# Altium schematic sheet MCU_USB_NEGOTIATOR.SchDoc
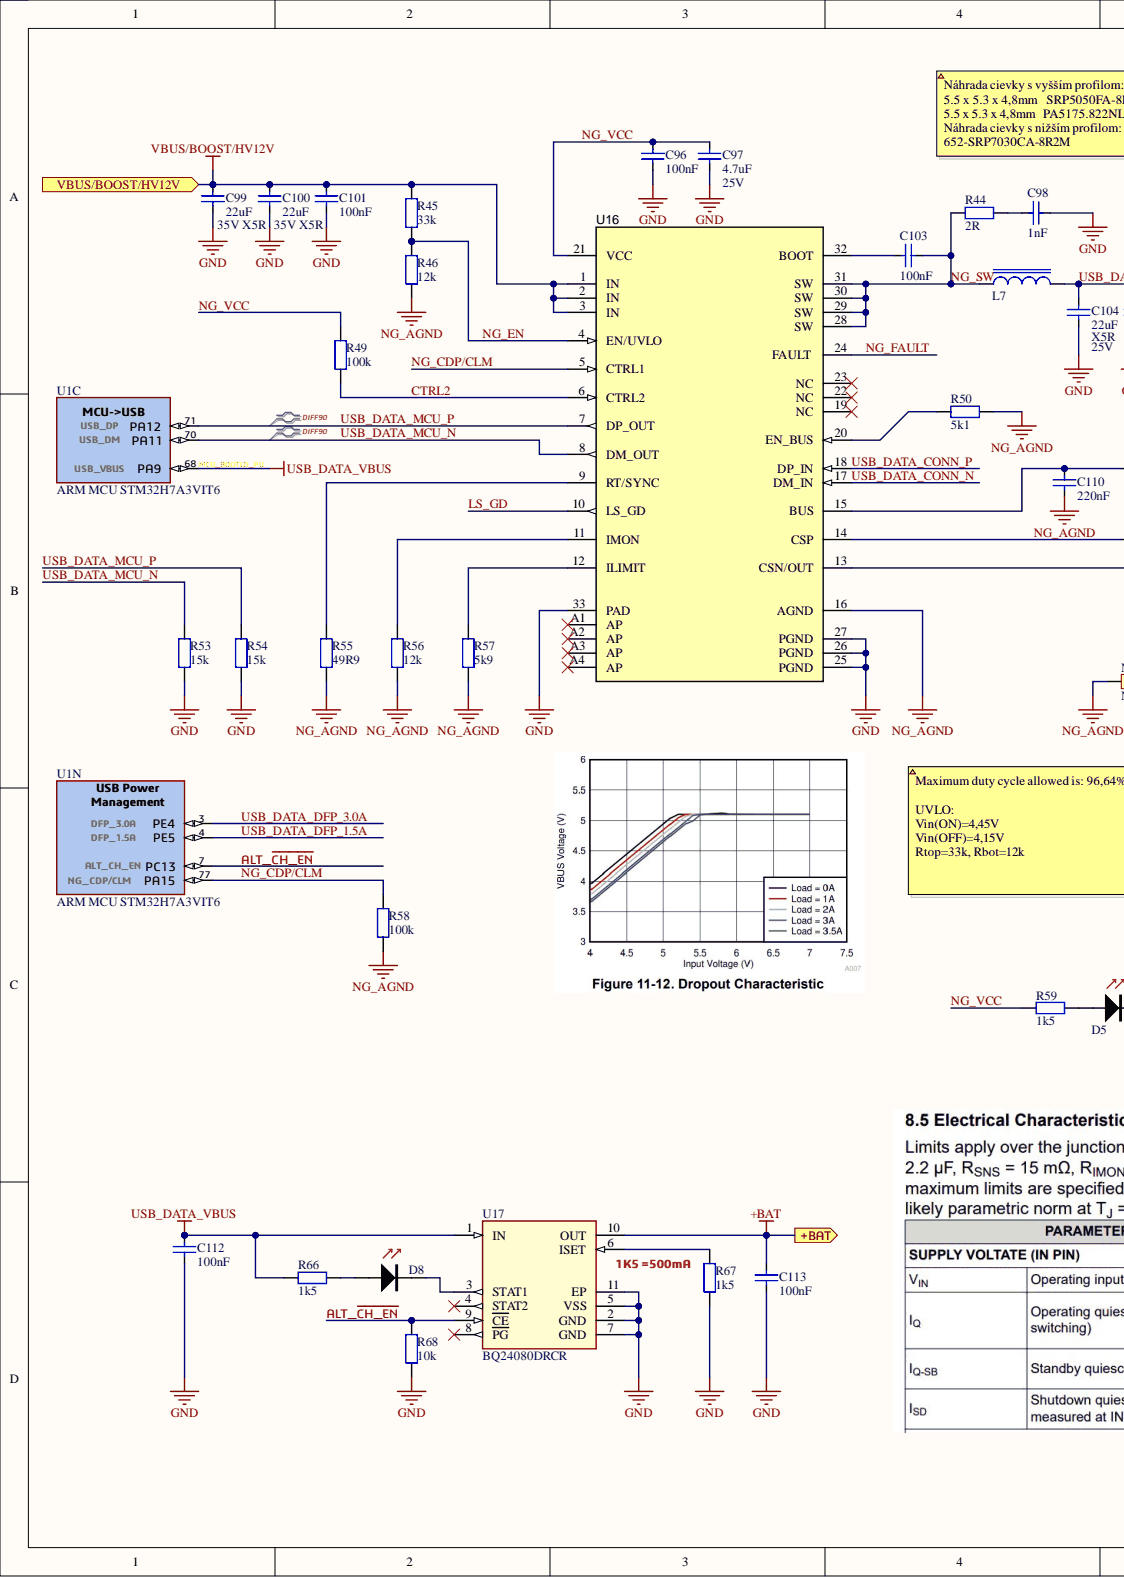
[diagram p0: MCU_USB_NEGOTIATOR.SchDoc, left]
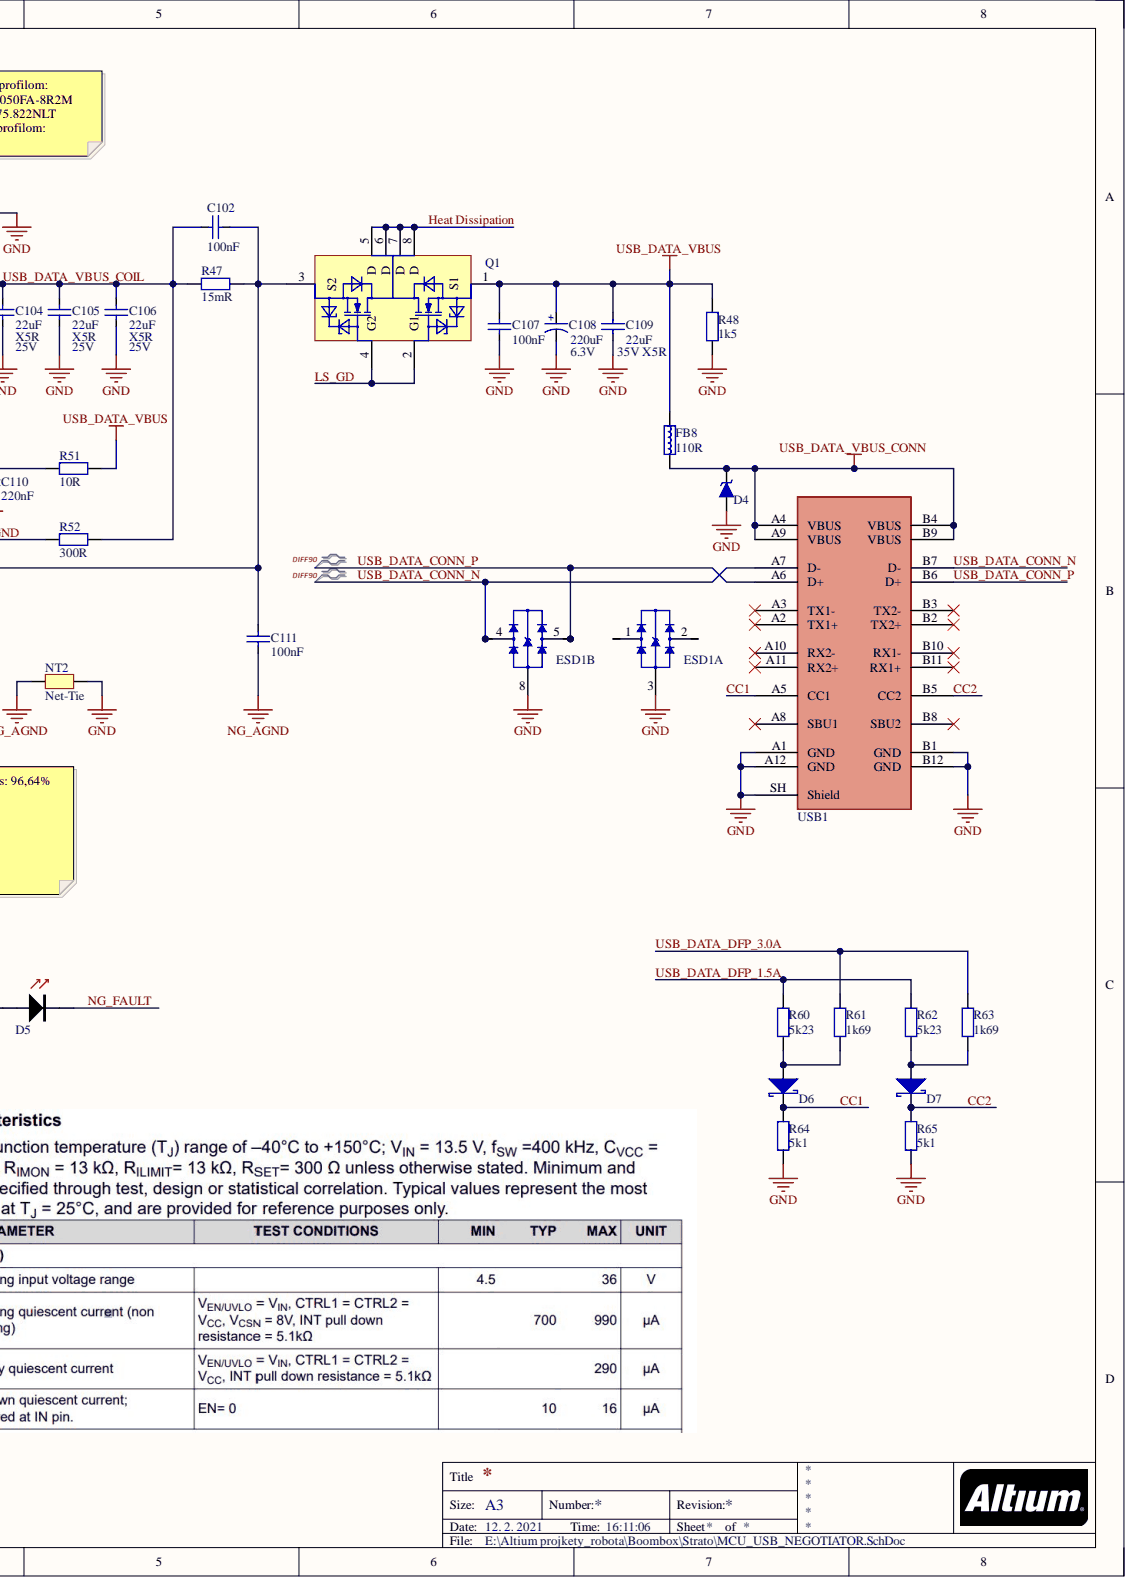
[diagram p1: MCU_USB_NEGOTIATOR.SchDoc, right]

## Parts
C96  100nF  [CAPACITOR_0402_(1005)]
C97  4.7uF  Voltage: 25V  [CAPACITOR_0603_(1608)]
C98  1nF  [CAPACITOR_0603_(1608)]
C99  22uF  Voltage Rating (DC): 35V  [CAPACITOR_1206_(3216)]
C100  22uF  Voltage Rating: 35V X5R  Voltage Rating (DC): 35V  [CAPACITOR_1206_(3216)]
C101  100nF  [CAPACITOR_0402_(1005)]
C102  100nF  [CAPACITOR_0402_(1005)]
C103  100nF  [CAPACITOR_0402_(1005)]
C104  22uF  Dielectric: X5R  Voltage: 25V  [CAPACITOR_0805_(2012)]
C105  22uF  Dielectric: X5R  Voltage: 25V  [CAPACITOR_0805_(2012)]
C106  22uF  Dielectric: X5R  Voltage: 25V  [CAPACITOR_0805_(2012)]
C107  100nF  [CAPACITOR_0402_(1005)]
C108  220uF  Voltage Rating: 6.3V  [Cap_tantalum Case B 3528-21]
C109  22uF  Voltage Rating: 35V X5R  Voltage Rating (DC): 35V  [CAPACITOR_1206_(3216)]
C110  220nF  [CAPACITOR_0603_(1608)]
C111  100nF  [CAPACITOR_0402_(1005)]
C112  100nF  [CAPACITOR_0402_(1005)]
C113  100nF  [CAPACITOR_0402_(1005)]
D4  Transient Voltage Suppressor  [MicroSMP DO-219AD]  (value not drawn)
D5  LED RED  [LED_0805_RED]  (value not drawn)
D6  NSR10F30NXT5G  [D_DSN2_(0502)]  (value not drawn)
D7  NSR10F30NXT5G  [D_DSN2_(0502)]  (value not drawn)
D8  LED RED  [LED_0805_RED]  (value not drawn)
ESD1  ESD Suppressor  [DQA10]  (value not drawn)  (parts A,B)
FB8  110R  [FERRITE BEAD_1206_(3216)]
L7  SRP5050FA-8R2M  [Power Inductor_SRP5050FA(SMD)  Bourns]
NT2  Net-Tie  [Net-Tie]  (no BOM)
Q1  ECH8693R-TL-W  [SOT-28FL / ECH8]  (value not drawn)
R44  2R  [RESISTOR_0603_(1608)]
R45  33k  [RESISTOR_0603_(1608)]
R46  12k  [RESISTOR_0603_(1608)]
R47  15mR  [RESISTOR_1206_(3216)]
R48  1k5  [RESISTOR_0603_(1608)]
R49  100k  [RESISTOR_0603_(1608)]
R50  5k1  [RESISTOR_0603_(1608)]
R51  10R  [RESISTOR_0603_(1608)]
R52  300R  [RESISTOR_0603_(1608)]
R53  15k  [RESISTOR_0402_(1005)]
R54  15k  [RESISTOR_0402_(1005)]
R55  49R9  [RESISTOR_0603_(1608)]
R56  12k  [RESISTOR_0603_(1608)]
R57  5k9  [RESISTOR_0603_(1608)]
R58  100k  [RESISTOR_0603_(1608)]
R59  1k5  [RESISTOR_0603_(1608)]
R60  5k23  [RESISTOR_0603_(1608)]
R61  1k69  [RESISTOR_0603_(1608)]
R62  5k23  [RESISTOR_0603_(1608)]
R63  1k69  [RESISTOR_0603_(1608)]
R64  5k1  [RESISTOR_0603_(1608)]
R65  5k1  [RESISTOR_0603_(1608)]
R66  1k5  [RESISTOR_0603_(1608)]
R67  1k5  [RESISTOR_0603_(1608)]
R68  10k  [RESISTOR_0603_(1608)]
U1  ARM MCU STM32H7A3VIT6  Manufacturer Part Number: STM32H7A3VIT6  [STM-LQFP100_N - Edited]  (parts C,N)
U16  TPS25846-Q1  [VQFN32-RHB 32]  (value not drawn)
U17  BQ24080DRCR  [VSON10]
USB1  DX07W024JA1R1000  [USB Type C DX07W024JA1]  (value not drawn)

## Ports
+BAT, VBUS/BOOST/HV12V

## Nets
GND: C96.2 C97.2 C98.2 C99.2 C100.2 C101.2 C104.1 C105.1 C106.1 C107.2 C108.1 C109.2 C112.2 C113.2 D4.1 ESD1.3 ESD1.8 NT2.2 R48.1 R53.2 R54.2 R64.2 R65.2 R67.2 R68.1 U16.25(PGND) U16.26(PGND) U16.27(PGND) U16.33(PAD) U17.2(GND) U17.5(VSS) U17.7(GND) U17.11(EP) USB1.A1(GND) USB1.A12(GND) USB1.B1(GND) USB1.B12(GND) USB1.SH(Shield)
NG_AGND: C110.1 C111.1 NT2.1 R46.2 R50.2 R55.2 R56.2 R57.2 R58.1 U16.16(AGND)
USB_DATA_VBUS: C107.1 C108.2 C109.1 C112.1 FB8.1 Q1.1(S1) R48.2 R51.2 R66.1 U1.68(PA9) U17.1(IN)
USB_DATA_VBUS_CONN: D4.2 FB8.2 USB1.A4(VBUS) USB1.A9(VBUS) USB1.B4(VBUS) USB1.B9(VBUS)
+BAT: C113.1 U17.10(OUT) ; port +BAT
ALT_~{CH_EN}: R68.2 U1.7(PC13) U17.9(CE)
CC1: D6.1 R64.1 USB1.A5(CC1)
CC2: D7.1 R65.1 USB1.B5(CC2)
CTRL2: R49.1 U16.6(CTRL2)
Heat Dissipation: Q1.5(D) Q1.6(D) Q1.7(D) Q1.8(D)
LS_GD: Q1.2(G1) Q1.4(G2) U16.10(LS_GD)
NG_CDP/CLM: R58.2 U1.77(PA15) U16.5(CTRL1)
NG_EN: R45.2 R46.1 U16.4(EN/UVLO)
NG_FAULT: D5.K U16.24(FAULT)
NG_SW: C103.2 L7.1 R44.1 U16.28(SW) U16.29(SW) U16.30(SW) U16.31(SW)
NG_VCC: C96.1 C97.1 R49.2 R59.1 U16.21(VCC)
USB_DATA_CONN_N: ESD1.4 ESD1.7 U16.17(DM_IN) USB1.A7(D-) USB1.B7(D-)
USB_DATA_CONN_P: ESD1.5 ESD1.6 U16.18(DP_IN) USB1.A6(D+) USB1.B6(D+)
USB_DATA_DFP_1.5A: R60.1 R62.1 U1.4(PE5)
USB_DATA_DFP_3.0A: R61.1 R63.1 U1.3(PE4)
USB_DATA_MCU_N: R53.1 U1.70(PA11) U16.8(DM_OUT)
USB_DATA_MCU_P: R54.1 U1.71(PA12) U16.7(DP_OUT)
USB_DATA_VBUS_COIL: C102.1 C104.2 C105.2 C106.2 L7.2 R47.2 R52.2
VBUS/BOOST/HV12V: C99.1 C100.1 C101.1 R45.1 U16.1(IN) U16.2(IN) U16.3(IN) ; port VBUS/BOOST/HV12V
NetC98_1: C98.1 R44.2
NetC102_2: C102.2 C111.2 Q1.3(S2) R47.1 U16.13(CSN/OUT)
NetC103_1: C103.1 U16.32(BOOT)
NetC110_2: C110.2 R51.1 U16.15(BUS)
NetD5_A: D5.A R59.2
NetD6_2: D6.2 R60.2 R61.2
NetD7_2: D7.2 R62.2 R63.2
NetD8_A: D8.A R66.2
NetD8_K: D8.K U17.3(STAT1)
NetESD1_1: ESD1.1 ESD1.10
NetESD1_2: ESD1.2 ESD1.9
NetR50_1: R50.1 U16.20(EN_BUS)
NetR52_1: R52.1 U16.14(CSP)
NetR55_1: R55.1 U16.9(RT/SYNC)
NetR56_1: R56.1 U16.11(IMON)
NetR57_1: R57.1 U16.12(ILIMIT)
NetR67_1: R67.1 U17.6(ISET)

Not connected: U16 19(NC) 22(NC) 23(NC) A1(AP) A2(AP) A3(AP) A4(AP); U17 4(STAT2) 8(PG); USB1 A2(TX1+) A3(TX1-) A8(SBU1) A10(RX2-) A11(RX2+) B2(TX2+) B3(TX2-) B8(SBU2) B10(RX1-) B11(RX1+)

## Library data of the parts
C96 C98 C101-C103 C107 C110-C113 = CAPACITOR: ESR: 0.28R; Voltage Rating: 10V; Component Kind: Standard; Description: CAPACITOR; Library Name: RLCFB.SchLib
  C96 C101-C103 C107 C111-C113: Capacitance: 100nF
  C98: Capacitance: 1nF
  C110: Capacitance: 220nF
C97 = CAPACITOR: Manufacturer: Murata; Manufacturer Part Number 1: GRM188R61E475KE11D; Dielectric: X5R; ESR: 0.28R; Material: Ceramic; Tolerance: 10%; Capacitance: 4.7uF; Case Code (Imperial): 0603; Case Code (Metric): 1608; Case/Package: 0603; Component Kind: Standard; ComponentLink1Description: https://datasheet.ciiva.com/46444/1769893-46444982.pdf?src-supplier=Element14; ComponentLink1URL: https://datasheet.ciiva.com/46444/1769893-46444982.pdf?src-supplier=Element14; ComponentLink2Description: https://datasheet.ciiva.com/46444/1769893-46444983.pdf?src-supplier=Element14; ComponentLink2URL: https://datasheet.ciiva.com/46444/1769893-46444983.pdf?src-supplier=Element14; ComponentLink3Description: https://datasheet.ciiva.com/14891/partnumbering-e-01-14891102.pdf?src-supplier=Digi-Key; ComponentLink3URL: https://datasheet.ciiva.com/14891/partnumbering-e-01-14891102.pdf?src-supplier=Digi-Key; ComponentLink4Description: https://datasheet.ciiva.com/14891/partnumbering-e-01-14891103.pdf?src-supplier=Digi-Key; ComponentLink4URL: https://datasheet.ciiva.com/14891/partnumbering-e-01-14891103.pdf?src-supplier=Digi-Key; Depth: 0.8mm; Description: CAPACITOR; Height: 0.8mm; Lead Free: Lead Free; Length: 1.6mm; Max Operating Temperature: 85°C; Min Operating Temperature: -55°C; Mount: Surface Mount; Package Quantity: 4000; Packaging: Cut Tape; Pricing 1: 10=0,35700, 100=100,00000, 500=0,15900, 1000=0,12800, 2000=0,10200 (CHF); REACH SVHC: No SVHC; RoHS: Yes; Stock 1: 95119; Supplier 1: Farnell; Supplier Part Number 1: 2426959; Thickness: 0.031inch; Voltage Rating: 25V; Voltage Rating (DC): 25V; Width: 0.031inch; Library Name: RLCFB.SchLib
C99 = CAPACITOR: Manufacturer: TDK; Manufacturer Part Number 1: C3216JB1V226M160AC; Dielectric Material: Ceramic; ESR: 0.28R; Tolerance: 20%; Voltage Rating: 35V X5R; Capacitance: 22uF; Case Code (Imperial): 1206; Case Code (Metric): 3216; Case/Package: 1206; Component Kind: Standard; ComponentLink1Description: http://www.mouser.com/ds/2/400/lcc_commercial_general_en-837201.pdf?src-supplier=Mouser; ComponentLink1URL: http://www.mouser.com/ds/2/400/lcc_commercial_general_en-837201.pdf?src-supplier=Mouser; ComponentLink2Description: https://product.tdk.com/info/en/catalog/spec/mlccspec_commercial_general_midvoltage_en.pdf?src-supplier=Digi-Key; ComponentLink2URL: https://product.tdk.com/info/en/catalog/spec/mlccspec_commercial_general_midvoltage_en.pdf?src-supplier=Digi-Key; ComponentLink3Description: http://product.tdk.com/en/catalog/datasheets/mlcc_commercial_general_en.pdf?src-supplier=Avnet; ComponentLink3URL: http://product.tdk.com/en/catalog/datasheets/mlcc_commercial_general_en.pdf?src-supplier=Avnet; Composition: Ceramic; Description: CAPACITOR; Height: 1.6mm; Lead Free: Lead Free; Length: 0.126inch; Max Operating Temperature: 85°C; Min Operating Temperature: -25°C; Mount: Surface Mount; Package Quantity: 2000; Packaging: Tape and Reel; Pricing 1: 1=1,12000, 10=0,84700, 50=0,84700, 100=0,59600, 1000=0,45200, 10000=0,28700 (USD); RoHS: Yes; Stock 1: 128007; Supplier 1: Mouser; Supplier Part Number 1: 810-C3216JB1V226M16C; Termination: SMD/SMT; Thickness: 0.069inch; Width: 0.063inch; Library Name: RLCFB.SchLib
C100 C109 = CAPACITOR: Manufacturer: TDK; Manufacturer Part Number 1: C3216JB1V226M160AC; Dielectric Material: Ceramic; ESR: 0.28R; Tolerance: 20%; Capacitance: 22uF; Case Code (Imperial): 1206; Case Code (Metric): 3216; Case/Package: 1206; Component Kind: Standard; ComponentLink1Description: http://www.mouser.com/ds/2/400/lcc_commercial_general_en-837201.pdf?src-supplier=Mouser; ComponentLink1URL: http://www.mouser.com/ds/2/400/lcc_commercial_general_en-837201.pdf?src-supplier=Mouser; ComponentLink2Description: https://product.tdk.com/info/en/catalog/spec/mlccspec_commercial_general_midvoltage_en.pdf?src-supplier=Digi-Key; ComponentLink2URL: https://product.tdk.com/info/en/catalog/spec/mlccspec_commercial_general_midvoltage_en.pdf?src-supplier=Digi-Key; ComponentLink3Description: http://product.tdk.com/en/catalog/datasheets/mlcc_commercial_general_en.pdf?src-supplier=Avnet; ComponentLink3URL: http://product.tdk.com/en/catalog/datasheets/mlcc_commercial_general_en.pdf?src-supplier=Avnet; Composition: Ceramic; Description: CAPACITOR; Height: 1.6mm; Lead Free: Lead Free; Length: 0.126inch; Max Operating Temperature: 85°C; Min Operating Temperature: -25°C; Mount: Surface Mount; Package Quantity: 2000; Packaging: Tape and Reel; Pricing 1: 1=1,12000, 10=0,84700, 50=0,84700, 100=0,59600, 1000=0,45200, 10000=0,28700 (USD); RoHS: Yes; Stock 1: 128007; Supplier 1: Mouser; Supplier Part Number 1: 810-C3216JB1V226M16C; Termination: SMD/SMT; Thickness: 0.069inch; Width: 0.063inch; Library Name: RLCFB.SchLib
C104-C106 = CAPACITOR: Manufacturer: Murata; Manufacturer Part Number 1: GRM21BR61E226ME44L; Material: Ceramic; Tolerance: 20%; Capacitance: 22uF; Case Code (Imperial): 0805; Case Code (Metric): 2012; Case/Package: 0805; Component Kind: Standard; ComponentLink1Description: https://datasheet.ciiva.com/22787/1747471-22787265.pdf?src-supplier=Farnell; ComponentLink1URL: https://datasheet.ciiva.com/22787/1747471-22787265.pdf?src-supplier=Farnell; ComponentLink2Description: https://datasheet.ciiva.com/7719/1747471-7719095.pdf?src-supplier=Element14; ComponentLink2URL: https://datasheet.ciiva.com/7719/1747471-7719095.pdf?src-supplier=Element14; ComponentLink3Description: https://datasheet.ciiva.com/28584/1747471-28584199.pdf?src-supplier=Element14; ComponentLink3URL: https://datasheet.ciiva.com/28584/1747471-28584199.pdf?src-supplier=Element14; Composition: Ceramic; Depth: 1.25mm; Description: CAPACITOR; Height: 1.25mm; Lead Free: Lead Free; Length: 2mm; Max Operating Temperature: 85°C; Min Operating Temperature: -55°C; Mount: Surface Mount; Package Quantity: 3000; Packaging: Tape and Reel; Pricing 1: 5=0,42800, 50=0,25100, 250=0,20300, 500=0,15000, 1500=0,12800 (EUR); REACH SVHC: No SVHC; RoHS: Yes; Stock 1: 70400; Supplier 1: Farnell; Supplier Part Number 1: 1907510; Termination: SMD/SMT; Thickness: 0.057inch; Voltage Rating: 25V; Voltage Rating (DC): 25V; Weight: 0.000335oz; Width: 1.25mm; Library Name: RLCFB.SchLib
C108 = Cap Pol: Tantalum Polymer Capacitor; Manufacturer: KEMET; Manufacturer Part Number: T520B227M006ATE045; Dissipation Factor: 8%; ESR (Equivalent Series Resistance): 45mR; Life (Hours): 2000Hour; Polarity: Polar; Tolerance: 20%; Capacitance: 220uF; Case Code (Metric): 3528; Component Kind: Standard; Depth: 2.8mm; Features: General Purpose; Height: 2.1mm; Height - Seated (Max): 0.083inch; Length: 3.5mm; Library Name: Source.SchLib; Max Operating Temperature: 105°C; Min Operating Temperature: -55°C; Mount: Surface Mount; Number of Pins: 2; Package Quantity: 1; Packaging: Tape and Reel; Pricing 1: 5 = 0,92700, 50 = 0,49000, 250 = 0,43000, 500 = 0,39100, 1000 = 0,32700, 2000 = 0,27500, 10000 = 0,26900, 20000 = 0,26700 (EUR); Radiation Hardening: No; REACH SVHC: No SVHC; RoHS: Yes; Stock 1: 1234; Supplier 1: Farnell; Supplier Part Number 1: 2535302; Termination: SMD/SMT; Voltage Rating (DC): 6.3V; Width: 0.11inch
D4 = D_TVS_MSP5.0A-M3/89A: MSP5.0A-M3/89A -  TVS Diode, TRANSZORB eSMP Series, Unidirectional, 5 V, 13.4 V, MicroSMP, 2 Pins; Manufacturer: Vishay Semiconductors; Breakdown Voltage: 7.07V; Clamping Voltage: 13.4V; Element Configuration: Single; ESD Protection: Yes; Leakage Current: 100uA; Max Surge Current: 57A; Min Breakdown Voltage: 6.4V; Number of Channels: 1; Operating Supply Voltage: 5V; Peak Pulse Current: 75A; Peak Pulse Power: 150W; Power Dissipation: 100W; Power Line Protection: No; Test Current: 1mA; Component Kind: Standard; ComponentLink1Description: https://datasheet.ciiva.com/3023/msp3v3-3023073.pdf?src-supplier=Avnet; ComponentLink1URL: https://datasheet.ciiva.com/3023/msp3v3-3023073.pdf?src-supplier=Avnet; ComponentLink2Description: https://datasheet.ciiva.com/17019/0900766b80ed1e45-17019980.pdf?src-supplier=Allied+Electronics; ComponentLink2URL: https://datasheet.ciiva.com/17019/0900766b80ed1e45-17019980.pdf?src-supplier=Allied+Electronics; ComponentLink3Description: https://datasheet.ciiva.com/16464/msp3v3-16464165.pdf?src-supplier=Future+Electronics; ComponentLink3URL: https://datasheet.ciiva.com/16464/msp3v3-16464165.pdf?src-supplier=Future+Electronics; ComponentLink4Description: https://datasheet.ciiva.com/26212/msp3v3-26212042.pdf?src-supplier=Avnet+Europe; ComponentLink4URL: https://datasheet.ciiva.com/26212/msp3v3-26212042.pdf?src-supplier=Avnet+Europe; ComponentLink5Description: https://datasheet.ciiva.com/330/msp5-0a-330477.pdf?src-supplier=Digi-Key; ComponentLink5URL: https://datasheet.ciiva.com/330/msp5-0a-330477.pdf?src-supplier=Digi-Key; ComponentLink6Description: https://datasheet.ciiva.com/330/msp5-0a-330478.pdf?src-supplier=Digi-Key; ComponentLink6URL: https://datasheet.ciiva.com/330/msp5-0a-330478.pdf?src-supplier=Digi-Key; ComponentLink7Description: https://datasheet.ciiva.com/330/msp5-0a-330479.pdf?src-supplier=Digi-Key; ComponentLink7URL: https://datasheet.ciiva.com/330/msp5-0a-330479.pdf?src-supplier=Digi-Key; Composition: Zener; Direction: Unidirectional; Height: 0.73mm; Lead Free: Lead Free; Length: 2.3mm; Library Name: Source.SchLib; Manufacturer Part Number 1: MSP5.0A-M3/89A; Max Breakdown Voltage: 7.07V; Max Operating Temperature: 150°C; Max Reverse Leakage Current: 100uA; Min Operating Temperature: -55°C; Mount: Surface Mount; Number of Elements: 1; Number of Pins: 2; Number of Unidirectional Channels: 1; Package Quantity: 1; Packaging: Tape and Reel; Polarity: Unidirectional; Pricing 1: 5=0,27600, 25=0,26600, 100=0,12300, 250=0,11800, 500=0,10100, 1000=0,05250, 5000=0,04220 (EUR); Radiation Hardening: No; Reverse Breakdown Voltage: 6.4V; Reverse Standoff Voltage: 5V; RoHS: Yes; Stock 1: 2631; Supplier 1: Farnell; Supplier Part Number 1: 2889195; Termination: SMD/SMT; Width: 1.4mm
D5 D8 = LED RED 0805: KPT-2012EC -  LED, Low Power, Red, SMD, 0805, 2 mA, 2 V, 617 nm; Manufacturer: Kingbright; Dominant Wavelength: 617nm; Forward Current: 20mA; Forward Voltage: 2V; Lens Color: Clear; Lens Style: Diffused; Luminous Intensity: 8mcd; Number of LEDs: 1; Viewing Angle: 120°; Case/Package: 2012; Color: Red; Component Kind: Standard; ComponentLink1Description: https://datasheet.ciiva.com/8060/1563702-8060626.pdf?src-supplier=Element14; ComponentLink1URL: https://datasheet.ciiva.com/8060/1563702-8060626.pdf?src-supplier=Element14; Library Name: Source.SchLib; Manufacturer Part Number 1: KPT-2012EC; Mount: Surface Mount; Number of Pins: 2; Packaging: Cut Tape; Pricing 1: 5=0,15600, 50=0,14200, 100=0,09300, 500=0,07860, 1000=0,06180 (EUR); Radiation Hardening: No; REACH SVHC: No SVHC; RoHS: Yes; Stock 1: 30789; Supplier 1: Farnell; Supplier Part Number 1: 2099236; Wavelength: 617nm
D6 D7 = D_NSR10F30NXT5G: DIODE SCHOTTKY 30V 1A 2DSN; Manufacturer: ON Semiconductor; Element Configuration: Single; Forward Voltage: 470mV; Max Forward Surge Current (Ifsm): 18A; Max Power Dissipation: 1.47W; Max Reverse Current: 100uA; Max Reverse Leakage Current: 20uA; Power Dissipation: 548mW; Average Rectified Current: 1A; Case/Package: 0502; Category: Schottky Diodes; Component Kind: Standard; ComponentLink1Description: https://datasheet.ciiva.com/917/nsr10f30-d-917057.pdf?src-supplier=Digi-Key; ComponentLink1URL: https://datasheet.ciiva.com/917/nsr10f30-d-917057.pdf?src-supplier=Digi-Key; ComponentLink2Description: https://datasheet.ciiva.com/4713/nsr10f30-97625-4713884.pdf?src-supplier=Mouser; ComponentLink2URL: https://datasheet.ciiva.com/4713/nsr10f30-97625-4713884.pdf?src-supplier=Mouser; ComponentLink3Description: https://datasheet.ciiva.com/917/nsr10f30-d-917058.pdf?src-supplier=Digi-Key; ComponentLink3URL: https://datasheet.ciiva.com/917/nsr10f30-d-917058.pdf?src-supplier=Digi-Key; ComponentLink4Description: https://datasheet.ciiva.com/917/nsr10f30-d-917059.pdf?src-supplier=Digi-Key; ComponentLink4URL: https://datasheet.ciiva.com/917/nsr10f30-d-917059.pdf?src-supplier=Digi-Key; ComponentLink5Description: https://datasheet.ciiva.com/16474/nsr10f30-d-16474534.pdf?src-supplier=Future+Electronics; ComponentLink5URL: https://datasheet.ciiva.com/16474/nsr10f30-d-16474534.pdf?src-supplier=Future+Electronics; Forward Current: 1A; Lead Free: Lead Free; Library Name: BB1_SCH_Library.SchLib; Manufacturer Part Number: NSR10F30NXT5G; Max Operating Temperature: 150°C; Max Repetitive Reverse Voltage (Vrrm): 30V; Max Reverse Voltage (DC): 30V; Max Surge Current: 18A; Min Operating Temperature: -40°C; Number of Pins: 2; Package Quantity: 5000; Packaging: Tape and Reel; Peak Non-Repetitive Surge Current: 18A; Pricing 1: 1=0,44000, 10=0,32200, 100=0,18700, 1000=0,12200, 5000=0,11200, 10000=0,10300 (USD); Radiation Hardening: No; RoHS: Yes; Stock 1: 10180; Supplier 1: Mouser; Supplier Part Number 1: 863-NSR10F30NXT5G
ESD1 = D_TPD4E05U06DQAR: TEXAS INSTRUMENTS - ESD Protection Device, 14 V, USON, 10 Pins, 5.5 V, TPD4E Series; Breakdown Voltage: 6.5V; Capacitance: 0.5pF; Clamping Voltage: 14V; Direction: Unidirectional; Interface: LVDS; Leakage Current: 10nA; Max Power Dissipation: 40W; Number of Bidirectional Channels: 4; Operating Supply Voltage: 5.5V; Peak Pulse Current: 5A; Power Line Protection: Yes; Component Kind: Standard; ComponentLink1Description: https://datasheet.ciiva.com/15063/tpd4e05u06-15063653.pdf?src-supplier=Digi-Key; ComponentLink1URL: https://datasheet.ciiva.com/15063/tpd4e05u06-15063653.pdf?src-supplier=Digi-Key; ComponentLink2Description: https://datasheet.ciiva.com/26918/getdatasheetpartid-716763-26918024.pdf?src-supplier=Verical; ComponentLink2URL: https://datasheet.ciiva.com/26918/getdatasheetpartid-716763-26918024.pdf?src-supplier=Verical; ComponentLink3Description: https://datasheet.ciiva.com/24119/slvsbo7f-316827-24119436.pdf?src-supplier=Mouser; ComponentLink3URL: https://datasheet.ciiva.com/24119/slvsbo7f-316827-24119436.pdf?src-supplier=Mouser; ComponentLink4Description: https://datasheet.ciiva.com/15063/tpd4e05u06-15063654.pdf?src-supplier=Digi-Key; ComponentLink4URL: https://datasheet.ciiva.com/15063/tpd4e05u06-15063654.pdf?src-supplier=Digi-Key; ComponentLink5Description: https://datasheet.ciiva.com/29883/tpd4e05u06-29883798.pdf?src-supplier=Avnet; ComponentLink5URL: https://datasheet.ciiva.com/29883/tpd4e05u06-29883798.pdf?src-supplier=Avnet; ComponentLink6Description: https://datasheet.ciiva.com/15063/tpd4e05u06-15063655.pdf?src-supplier=Digi-Key; ComponentLink6URL: https://datasheet.ciiva.com/15063/tpd4e05u06-15063655.pdf?src-supplier=Digi-Key; Contact Plating: Gold; Depth: 1.1mm; Lead Free: Lead Free; Length: 2.6mm; Library Name: Source.SchLib; Manufacturer: Texas Instruments; Manufacturer Part Number 1: TPD4E05U06DQAR; Max Operating Temperature: 125°C; Min Breakdown Voltage: 6.5V; Min Operating Temperature: -40°C; Mount: Surface Mount; Number of Channels: 4; Number of Pins: 10; Package Quantity: 3000; Packaging: Tape and Reel; Peak Pulse Power: 40W; Polarity: Unidirectional; Pricing 1: 1=0,61000, 10=0,50000, 50=0,50000, 100=0,32400, 1000=0,26000, 2000=0,23500, 3000=0,21900, 9000=0,21100, 10000=0,21100, 24000=0,20300, 48000=0,19500 (USD); Radiation Hardening: No; REACH SVHC: No SVHC; Reverse Standoff Voltage: 5.5V; RoHS: Yes; Stock 1: 7182; Supplier 1: Mouser; Supplier Part Number 1: 595-TPD4E05U06DQAR; Termination: SMD/SMT; Working Voltage: 5.5V
FB8 = FERRITE_BEAD: WURTH ELEKTRONIK - 74279221111 - Ferrite Bead, 1206 [3216 Metric], 110 ohm, 5.4 A, WE-MPSB Series, 0.015 ohm, ± 25%; Manufacturer: Wurth Electronics; DC Resistance (DCR): 15mR; Max Current Rating: 5.4A; Number of Lines: 1; Resistance: 0.015R; Test Frequency: 100MHz; Case Code (Imperial): 1206; Case Code (Metric): 3216; Case/Package: 1206; Category: Ferrite Beads and Chips; Component Kind: Standard; ComponentLink1Description: http://www.mouser.com/ds/2/445/74279221111-516318.pdf?src-supplier=Mouser; ComponentLink1URL: http://www.mouser.com/ds/2/445/74279221111-516318.pdf?src-supplier=Mouser; ComponentLink2Description: http://www.farnell.com/datasheets/1880876.pdf?src-supplier=Farnell; ComponentLink2URL: http://www.farnell.com/datasheets/1880876.pdf?src-supplier=Farnell; ComponentLink3Description: https://katalog.we-online.de/pbs/datasheet/74279221111.pdf?src-supplier=Digi-Key; ComponentLink3URL: https://katalog.we-online.de/pbs/datasheet/74279221111.pdf?src-supplier=Digi-Key; ComponentLink4Description: http://katalog.we-online.de/pbs/datasheet/74279221111.pdf?src-supplier=Digi-Key; ComponentLink4URL: http://katalog.we-online.de/pbs/datasheet/74279221111.pdf?src-supplier=Digi-Key; ComponentLink5Description: http://www.farnell.com/datasheets/1880876.pdf?src-supplier=Element14; ComponentLink5URL: http://www.farnell.com/datasheets/1880876.pdf?src-supplier=Element14; ComponentLink6Description: http://www.farnell.com/datasheets/1880876.pdf?src-supplier=Newark; ComponentLink6URL: http://www.farnell.com/datasheets/1880876.pdf?src-supplier=Newark; Height: 0.051inch; Impedance: 110R; Length: 0.126inch; Manufacturer Part Number: 74279221111; Max DC Current: 5.4A; Max Operating Temperature: 125°C; Min Operating Temperature: -55°C; Mount: Surface Mount; Package Quantity: 3000; Packaging: Tape and Reel; Pricing 1: 1=0,44000, 10=0,44000, 50=0,40700, 100=0,37000, 1000=0,30600, 10000=0,27800 (USD); REACH SVHC: Unknown; RoHS: Yes; Stock 1: 1674; Supplier 1: Mouser; Supplier Part Number 1: 710-74279221111; Termination: SMD/SMT; Tolerance: 25%; Weight: 0.000353oz; Width: 0.063inch; Library Name: RLCFB.SchLib
L7 = IND_SRP7030CA-2R2M: Ind, 5.5X5.3X4.8Mm, 8.2Uh 20%, 6.1A, shd, smd / Tape & Reel - 13" Reel; Manufacturer: Bourns; Component Kind: Standard; Library Name: BB1_SCH_Library.SchLib; Manufacturer Part Number: SRP5050FA-8R2M; Pricing 1: 1=1,31000, 10=1,21000, 50=0,94300, 100=0,88500, 1000=0,65700, 10000=0,63700 (USD); RoHS: No; Stock 1: 2985; Supplier 1: Mouser; Supplier Part Number 1: 652-SRP5050FA-8R2M
Q1 = ECH8693R: Dual N-Channel Power MOSFET, 24V, 14A, 7mΩ; Manufacturer: ON Semiconductor; Drain to Source Resistance: 7mR; Fall Time: 22200ns; FET Type: 2 N-Channel; Gate to Source Voltage (Vgs): 12.5V; Max Power Dissipation: 1.4W; Number of Channels: 2; Rise Time: 525ns; Turn-Off Delay Time: 18650ns; Turn-On Delay Time: 545ns; Case/Package: SMD/SMT; Category: MOSFETs; Component Kind: Standard; ComponentLink1Description: https://datasheet.ciiva.com/30022/ech8693r-d-30022230.pdf?src-supplier=Avnet; ComponentLink1URL: https://datasheet.ciiva.com/30022/ech8693r-d-30022230.pdf?src-supplier=Avnet; Contact Plating: Tin; Continuous Drain Current (ID): 14A; Drain to Source Breakdown Voltage: 24V; Drain to Source Voltage (Vdss): 24V; Lead Free: Lead Free; Library Name: BB1_SCH_Library.SchLib; Manufacturer Part Number: ECH8693R-TL-W; Max Operating Temperature: 150°C; Min Operating Temperature: -55°C; Mount: Surface Mount; Number of Pins: 8; Package Quantity: 3000; Packaging: Tape and Reel; Pricing 1: 5=0,46300, 10=0,36300, 100=0,23000, 250=0,20900, 500=0,18800, 1000=0,13200, 5000=0,12900 (GBP); Radiation Hardening: No; Rds On Max: 7mR; RoHS: Yes; Stock 1: 708; Supplier 1: Farnell; Supplier Part Number 1: 2774832
R44-R46 R48-R68 = RESISTOR: Power Rating: 500mW; Component Kind: Standard; Description: RESISTOR; Library Name: RLCFB.SchLib
  R44: Resistance: 2R
  R45: Resistance: 33k
  R46 R56: Resistance: 12k
  R48 R59 R66 R67: Resistance: 1k5
  R49 R58: Resistance: 100k
  R50 R64 R65: Resistance: 5k1
  R51: Resistance: 10R
  R52: Resistance: 300R
  R53 R54: Resistance: 15k
  R55: Resistance: 49R9
  R57: Resistance: 5k9
  R60 R62: Resistance: 5k23
  R61 R63: Resistance: 1k69
  R68: Resistance: 10k
R47 = RESISTOR: TT ELECTRONICS / WELWYN - LRMAM1206-R015FT5 - STROMMESSWIDERSTAND, 0R015, 1%, 1W, 1206; Manufacturer: TT Welwyn; Temperature Coefficient: 75ppm/°C; Case Code (Imperial): 1206; Case Code (Metric): 3216; Case/Package: Metal; Category: Chip SMD Resistors; Component Kind: Standard; ComponentLink1Description: https://datasheet.ciiva.com/7816/1518406-7816329.pdf?src-supplier=Element14; ComponentLink1URL: https://datasheet.ciiva.com/7816/1518406-7816329.pdf?src-supplier=Element14; Manufacturer Part Number: LRMAM1206-R015FT5; Packaging: Cut Tape; Power Rating: 1W; Pricing 1: 10=0,23200, 100=0,13900, 500=0,10600, 1000=0,09450, 2500=0,08200 (GBP); REACH SVHC: No SVHC; Resistance: 15mR; Resistor Type: Metal Alloy; RoHS: Yes; Stock 1: 6451; Supplier 1: Farnell; Supplier Part Number 1: 2079441; Tolerance: 1%; Library Name: RLCFB.SchLib
U1 = STM32H7A3VIT6_LQFP100: STM32H7A3VIT6 -  32-bit Arm® Cortex®-M7 280 MHz MCUs, up to 2-Mbyte Flash memory, 1.4Mbyte RAM, 46 com. and analog interfaces; Manufacturer: STMicroelectronics; Category: Microcontrollers; Component Kind: Standard; ComponentLink1Description: Datasheet; ComponentLink1URL: https://www.st.com/resource/en/datasheet/stm32h7a3vi.pdf; Library Name: BB1_SCH_Library.SchLib; RoHS: No; Supplier 1: Mouser; Supplier Part Number 1: 511-STM32H7A3VIT6
U16 = CMP-0082640-2: USB Type-A BC1.2 5-V 3.5-A Output, 36-V Input Synchronous Buck With Cable Compensation, RHB0032R (VQFN-32); Alternate Manufacturer: Texas Instruments; Manufacturer Part Number: TPS25846QWRHBRQ1; AEC Category: Q200; CompType: IC; Component Kind: Standard; ComponentLink1Description: Datasheet Link; ComponentLink1URL: http://www.ti.com/lit/ds/symlink/tps25840-q1.pdf; ComponentLink2Description: Product Folder; ComponentLink2URL: http://www.ti.com/product/tps25840-q1; ComponentLink3Description: Online Datasheet; ComponentLink3URL: http://www.ti.com/product/tps25840-q1/datasheet; Library Name: BB1_SCH_Library.SchLib; Manufacturer: Texas Instruments; MountType: SMT; PackageDescription: VQFN-32; PackageReference: RHB0032R; Pricing 1: 1=5,13000, 10=4,60500, 25=4,35360, 100=3,57980, 250=3,21212, 500=3,09600, 1000=2,57355 (USD); RoHS: No; Stock 1: 3000; Supplier 1: Digi-Key; Supplier Part Number 1: 296-TPS25846QWRHBRQ1CT-ND
U17 = Batt Charger BQ24080: 1-Cell Li-Ion Charger with 1 A FET, AC Present and Charge Enable, 4.5 V, -40 to 85 degC, 10-pin SON (DRC), Green (RoHS & no Sb/Br); Manufacturer: Texas Instruments; Max Charge Current: 1A; Max Output Current: 1.5A; Max Output Voltage: 4.2V; Max Power Dissipation: 1.5W; Max Supply Voltage: 6.5V; Min Input Voltage: 4.5V; Number of Cells: 1; Component Kind: Standard; ComponentLink1Description: https://datasheet.ciiva.com/4873/slus698e-128296-4873536.pdf?src-supplier=Mouser; ComponentLink1URL: https://datasheet.ciiva.com/4873/slus698e-128296-4873536.pdf?src-supplier=Mouser; ComponentLink2Description: https://datasheet.ciiva.com/1136/1768090-1136584.pdf?src-supplier=Element14; ComponentLink2URL: https://datasheet.ciiva.com/1136/1768090-1136584.pdf?src-supplier=Element14; ComponentLink3Description: https://datasheet.ciiva.com/15114/getdatasheetpartid-188154-15114504.pdf?src-supplier=Verical; ComponentLink3URL: https://datasheet.ciiva.com/15114/getdatasheetpartid-188154-15114504.pdf?src-supplier=Verical; ComponentLink4Description: https://datasheet.ciiva.com/1932/bq24081-1932212.pdf?src-supplier=Digi-Key; ComponentLink4URL: https://datasheet.ciiva.com/1932/bq24081-1932212.pdf?src-supplier=Digi-Key; ComponentLink5Description: https://datasheet.ciiva.com/1932/bq24081-1932213.pdf?src-supplier=Digi-Key; ComponentLink5URL: https://datasheet.ciiva.com/1932/bq24081-1932213.pdf?src-supplier=Digi-Key; ComponentLink6Description: https://datasheet.ciiva.com/1932/bq24081-1932214.pdf?src-supplier=Digi-Key; ComponentLink6URL: https://datasheet.ciiva.com/1932/bq24081-1932214.pdf?src-supplier=Digi-Key; ComponentLink7Description: https://datasheet.ciiva.com/3214/bq24080-3214054.pdf?src-supplier=Avnet; ComponentLink7URL: https://datasheet.ciiva.com/3214/bq24080-3214054.pdf?src-supplier=Avnet; Contact Plating: Gold; Lead Free: Lead Free; Library Name: BB1_SCH_Library.SchLib; Manufacturer Part Number 1: BQ24080DRCR; Max Operating Temperature: 125°C; Min Operating Temperature: -40°C; Min Supply Voltage: 4.5V; Number of Outputs: 1; Number of Pins: 10; Output Current: 1A; Output Voltage: 4.2V; Package Quantity: 1; Packaging: Tape and Reel; Pricing 1: 1=1,82000, 10=1,54000, 100=1,24000, 500=1,08000, 1000=0,89400, 3000=0,83200, 6000=0,80100, 9000=0,77000 (USD); Radiation Hardening: No; REACH SVHC: No SVHC; RoHS: Yes; Stock 1: 3814; Supplier 1: Mouser; Supplier Part Number 1: 595-BQ24080DRCR; Termination: SMD/SMT; Weight: 0.000921oz
USB1 = USB C JAE: CONN RCPT USB3.1 TYPEC 24POS SMD; Manufacturer: JAE Electronics; Connector Type: USB; Current Rating: 6.25A; Gender: Receptacle; Mating Cycles: 10000; Number of Contacts: 24; Number of Ports: 1; Ratings: Waterproof; Shielding: Shielded; Category: Connectors; Component Kind: Standard; ComponentLink1Description: http://www.jae.com/z-en/pdf_download_exec.cfm?param=SJ119582.pdf&src-supplier=Digi-Key; ComponentLink1URL: http://www.jae.com/z-en/pdf_download_exec.cfm?param=SJ119582.pdf&src-supplier=Digi-Key; ComponentLink2Description: http://www.jae.com/z-en/pdf_download_exec.cfm?param=SJ119583.pdf&src-supplier=Digi-Key; ComponentLink2URL: http://www.jae.com/z-en/pdf_download_exec.cfm?param=SJ119583.pdf&src-supplier=Digi-Key; Contact Plating: Gold; Insulation Color: Black; Library Name: BB1_SCH_Library.SchLib; Manufacturer Part Number: DX07W024JA1R1000; Max Operating Temperature: 80°C; Min Operating Temperature: -30°C; Mount: Surface Mount,Edge; Packaging: Cut Tape; Pricing 1: 1=3,75000, 10=3,15000, 100=3,00000, 250=2,46000, 500=2,39000, 1000=2,04000 (USD); RoHS: No; Supplier 1: Mouser; Supplier Part Number 1: 656-DX07W024JA1R1000; Termination: Solder
NT2 = Net-Tie: Description: Net-Tie; Library Name: BB1_SCH_Library.SchLib
